# Altium schematic sheet Power_board_1.SchDoc(Power_board_1)
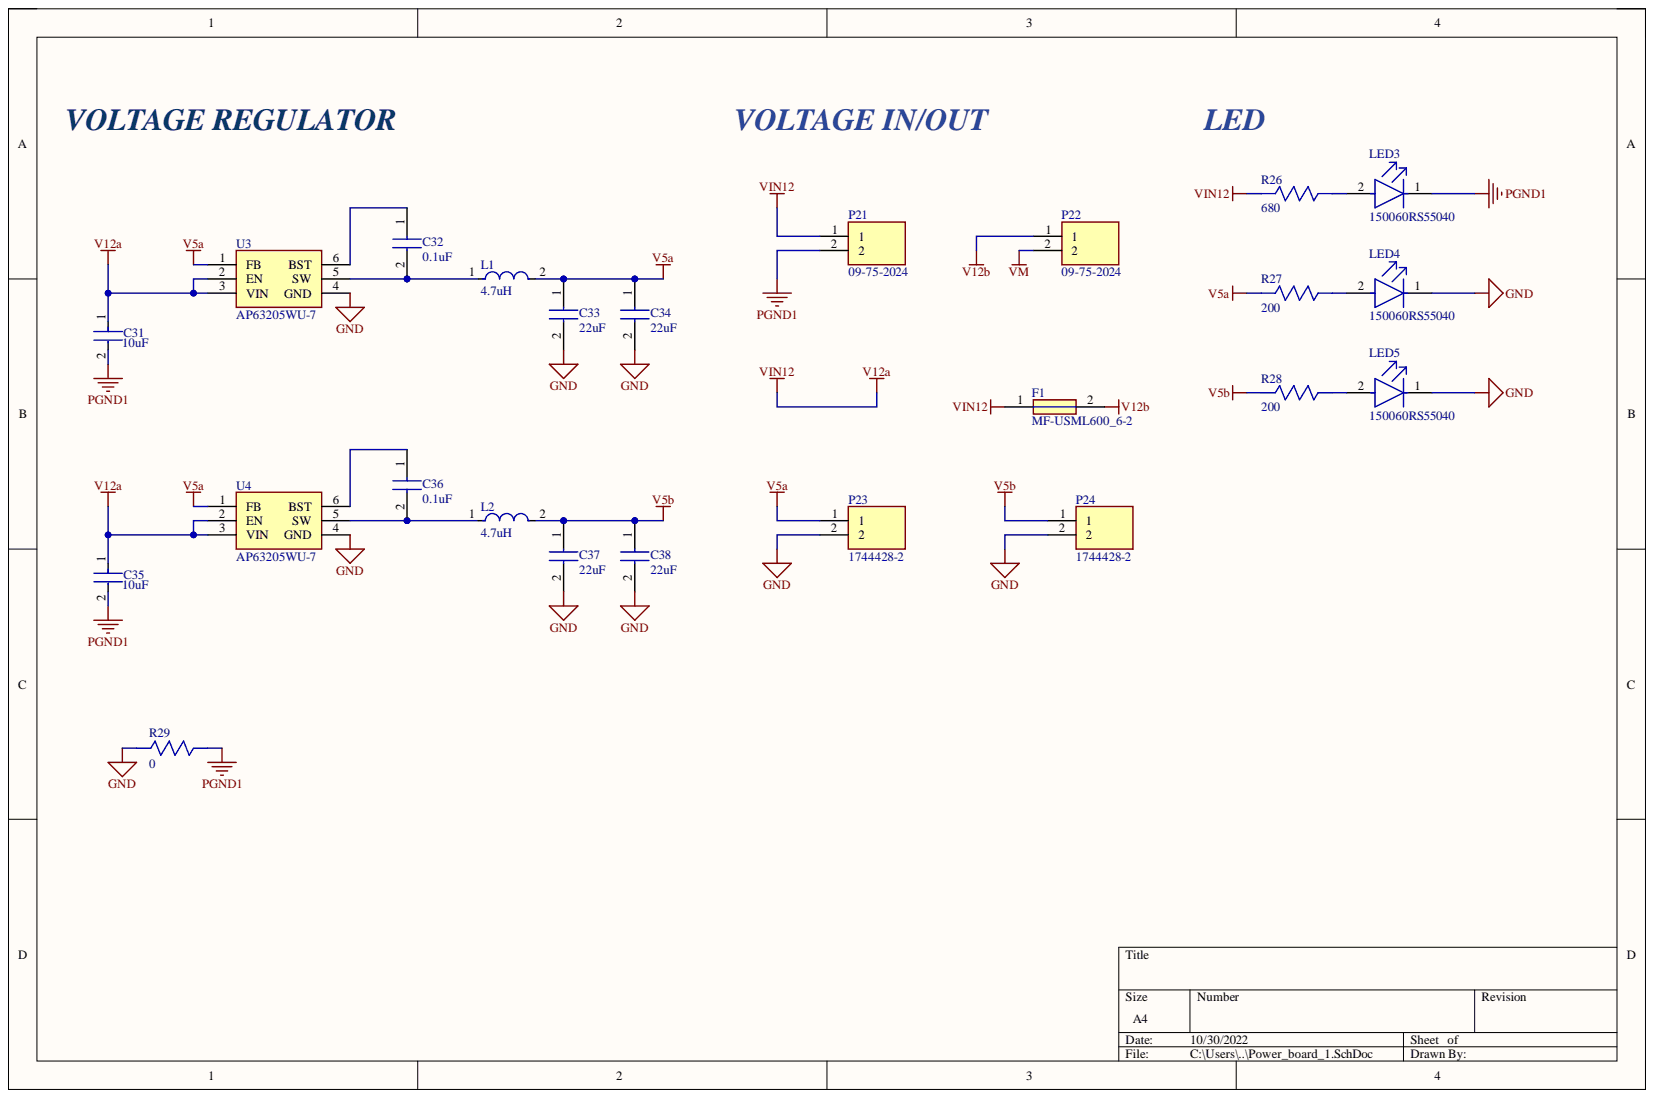
[diagram p0: Power_board_1.SchDoc]

## Parts
C31  10uF  [FP-C1608-080-IPC_A]
C32  0.1uF  [CAPC1608X90N]
C33  22uF  [C1608_Commercial]
C34  22uF  [C1608_Commercial]
C35  10uF  [FP-C1608-080-IPC_A]
C36  0.1uF  [CAPC1608X90N]
C37  22uF  [C1608_Commercial]
C38  22uF  [C1608_Commercial]
F1  MF-USML600_6-2  [FUSC3226X120N]
L1  4.7uH  [FP-NR6028-MFG]
L2  4.7uH  [FP-NR6028-MFG]
LED3  150060RS55040  [FP-150060RS55040-MFG]
LED4  150060RS55040  [FP-150060RS55040-MFG]
LED5  150060RS55040  [FP-150060RS55040-MFG]
P21  09-75-2024  [17444282]
P22  09-75-2024  [17444282]
P23  1744428-2  [17444282]
P24  1744428-2  [17444282]
R26  680  [RESC1608X55N]
R27  200  [RESC1608X55N]
R28  200  [RESC1608X55N]
R29  0  [RESC1608X55N]
U3  AP63205WU-7  [SOT95P280X100-6N]
U4  AP63205WU-7  [SOT95P280X100-6N]

## Nets
GND: C33.2 C34.2 C37.2 C38.2 LED4.1 LED5.1 P23.2 P24.2 R29.1 U3.4(GND) U4.4(GND)
PGND1: C31.2 C35.2 LED3.1 P21.2 R29.2
V5a: C33.1 C34.1 L1.2 P23.1 R27.1 U3.1(FB) U4.1(FB)
V5b: C37.1 C38.1 L2.2 P24.1 R28.1
V12a: C31.1 C35.1 F1.1 P21.1 R26.1 U3.2(EN) U3.3(VIN) U4.2(EN) U4.3(VIN)
V12b: F1.2 P22.1
VM: P22.2
NetC32_1: C32.1 U3.6(BST)
NetC32_2: C32.2 L1.1 U3.5(SW)
NetC36_1: C36.1 U4.6(BST)
NetC36_2: C36.2 L2.1 U4.5(SW)
NetLED3_2: LED3.2 R26.2
NetLED4_2: LED4.2 R27.2
NetLED5_2: LED5.2 R28.2

## Library data of the parts
C31 C35 = CMP-2000-05442-2: CAP CER 10UF 25V X5R 0603; Dielectric Material: Ceramic; Tolerance: 20%; Capacitance: 10uF; Case Code (Imperial): 0603; Case Code (Metric): 1608; Case/Package: 0603; Component Kind: Standard; Construction: Flat; Depth: 0.8mm; Dielectric: X5R; Height: 0.8mm; Lead Free: Lead Free; Length: 1.6mm; Library Name: Altium Content Vault; Max Operating Temperature: 85°C; Min Operating Temperature: -55°C; Mount: Surface Mount; Package Quantity: 1; Packaging: Tape and Reel; REACH SVHC: No SVHC; Resistor Type: Ceramic; RoHS Compliant: Yes; Series: C; Termination: SMD/SMT; Thickness: 0.039inch; Voltage Rating: 25V; Voltage Rating (DC): 25V; Weight: 7.1E-05oz; Width: 0.8mm
C32 C36 = GRM188R72A104MA35D: Capacitor; Manufacturer_Name: Murata Electronics; Arrow Part Number: GRM188R72A104MA35D; Arrow Price/Stock: https://www.arrow.com/en/products/grm188r72a104ma35d/murata-manufacturing?region=nac; Component Kind: Standard; Height: 0.9mm; Manufacturer_Part_Number: GRM188R72A104MA35D; Mouser Part Number: 81-GRM188R72A104MA5D; Mouser Price/Stock: https://www.mouser.co.uk/ProductDetail/Murata-Electronics/GRM188R72A104MA35D?qs=QdePRyQsNGaEtttrWFG%2FPQ%3D%3D
C33 C34 C37 C38 = C1608X5R1H104M080AA: Capacitor; Manufacturer_Name: TDK; Component Kind: Standard; Datasheet Link: https://product.tdk.com/system/files/dam/doc/product/capacitor/ceramic/mlcc/catalog/mlcc_commercial_general_en.pdf; Height: 0.9mm; Library Name: SamacSys.SchLib; Manufacturer_Part_Number: C1608X5R1H104M080AA
F1 = MF-USML600_6-2: Fuse; Manufacturer_Name: Bourns; Manufacturer_Part_Number: MF-USML600/6-2; Component Kind: Standard; Datasheet Link: https://www.bourns.com/docs/product-datasheets/mf-usml.pdf?sfvrsn=75cb6af6_18; Height: 1.2mm; Mouser Part Number: 652-MF-USML600/6-2; Mouser Price/Stock: https://www.mouser.com/Search/Refine.aspx?Keyword=652-MF-USML600%2F6-2
L1 L2 = CMP-14484-000746-1: SMD Power Inductors for Automotive Applications 4.7uH ±20% 2.7A 40.3mΩ; Core Material: Ferrite; Current Rating: 3A; DC Resistance (DCR): 40.3mR; Self Resonant Frequency: 39MHz; Shielding: Shielded; Test Frequency: 100kHz; Case/Package: Nonstandard; Component Kind: Standard; Depth: 6mm; Halogen Free: Halogen Free; Height: 2.8mm; Height - Seated (Max): 0.11inch; Inductance: 4.7uH; Length: 0.236inch; Library Name: Altium Content Vault; Max DC Current: 3A; Max Operating Temperature: 125°C; Min Operating Temperature: -25°C; Mount: Surface Mount; Package Quantity: 2000; Packaging: Tape and Reel; Radiation Hardening: No; Ratings: AEC-Q200; Resistor Type: Wirewound; RoHS Compliant: Yes; Saturation Current: 2.7A; Termination: SMD/SMT; Tolerance: 20%; Width: 6mm
LED3-LED5 = CMP-207942-000007-1: LED RED DIFFUSED 0603 SMD; Dominant Wavelength: 624nm; Forward Voltage: 2V; Lens Size: 0.8mm; Lens Style: Flat; Luminous Intensity: 90mcd; Test Current: 20mA; Viewing Angle: 140°; Case Code (Imperial): 0603; Case Code (Metric): 1608; Case/Package: 0603; Color: Red; Component Kind: Standard; Height: 0.5mm; Length: 1.6mm; Lens Transparency: Diffused; Library Name: Altium Content Vault; Mount: Surface Mount; Packaging: Cut Tape; RoHS Compliant: No; Width: 0.8mm
P21-P24 = 1744428-2: Connector; Manufacturer_Name: TE Connectivity; Component Kind: Standard; Datasheet Link: https://www.te.com/commerce/DocumentDelivery/DDEController?Action=srchrtrv&DocNm=1744428&DocType=Customer+Drawing&DocLang=English; Height: 8.8mm; Library Name: SamacSys.SchLib; Manufacturer_Part_Number: 1744428-2; Mouser Part Number: 571-1744428-2; Mouser Price/Stock: https://www.mouser.co.uk/ProductDetail/TE-Connectivity/1744428-2?qs=%2F6W%2F9%2FHWvcDoOfykajFB2Q%3D%3D
R26-R29 = CMP0603-FX-1003ELF: Resistor; Manufacturer_Name: Bourns; Component Kind: Standard; Datasheet Link: https://www.mouser.co.uk/datasheet/2/54/Bourns_CMP_Datasheet_05.28.20-1854233.pdf; Height: 0.55mm; Library Name: SamacSys.SchLib; Manufacturer_Part_Number: CMP0603-FX-1003ELF
U3 U4 = AP63205WU-7: Integrated Circuit; Manufacturer_Name: Diodes Inc.; Arrow Part Number: AP63205WU-7; Arrow Price/Stock: https://www.arrow.com/en/products/ap63205wu-7/diodes-incorporated?region=nac; Component Kind: Standard; Datasheet Link: https://www.diodes.com/assets/Datasheets/AP63200-AP63201-AP63203-AP63205.pdf; Height: 1mm; Manufacturer_Part_Number: AP63205WU-7; Mouser Part Number: 621-AP63205WU-7; Mouser Price/Stock: https://www.mouser.co.uk/ProductDetail/Diodes-Incorporated/AP63205WU-7?qs=u16ybLDytRZtkj8PzdWCOw%3D%3D
